# Altium schematic sheet Relay.SchDoc
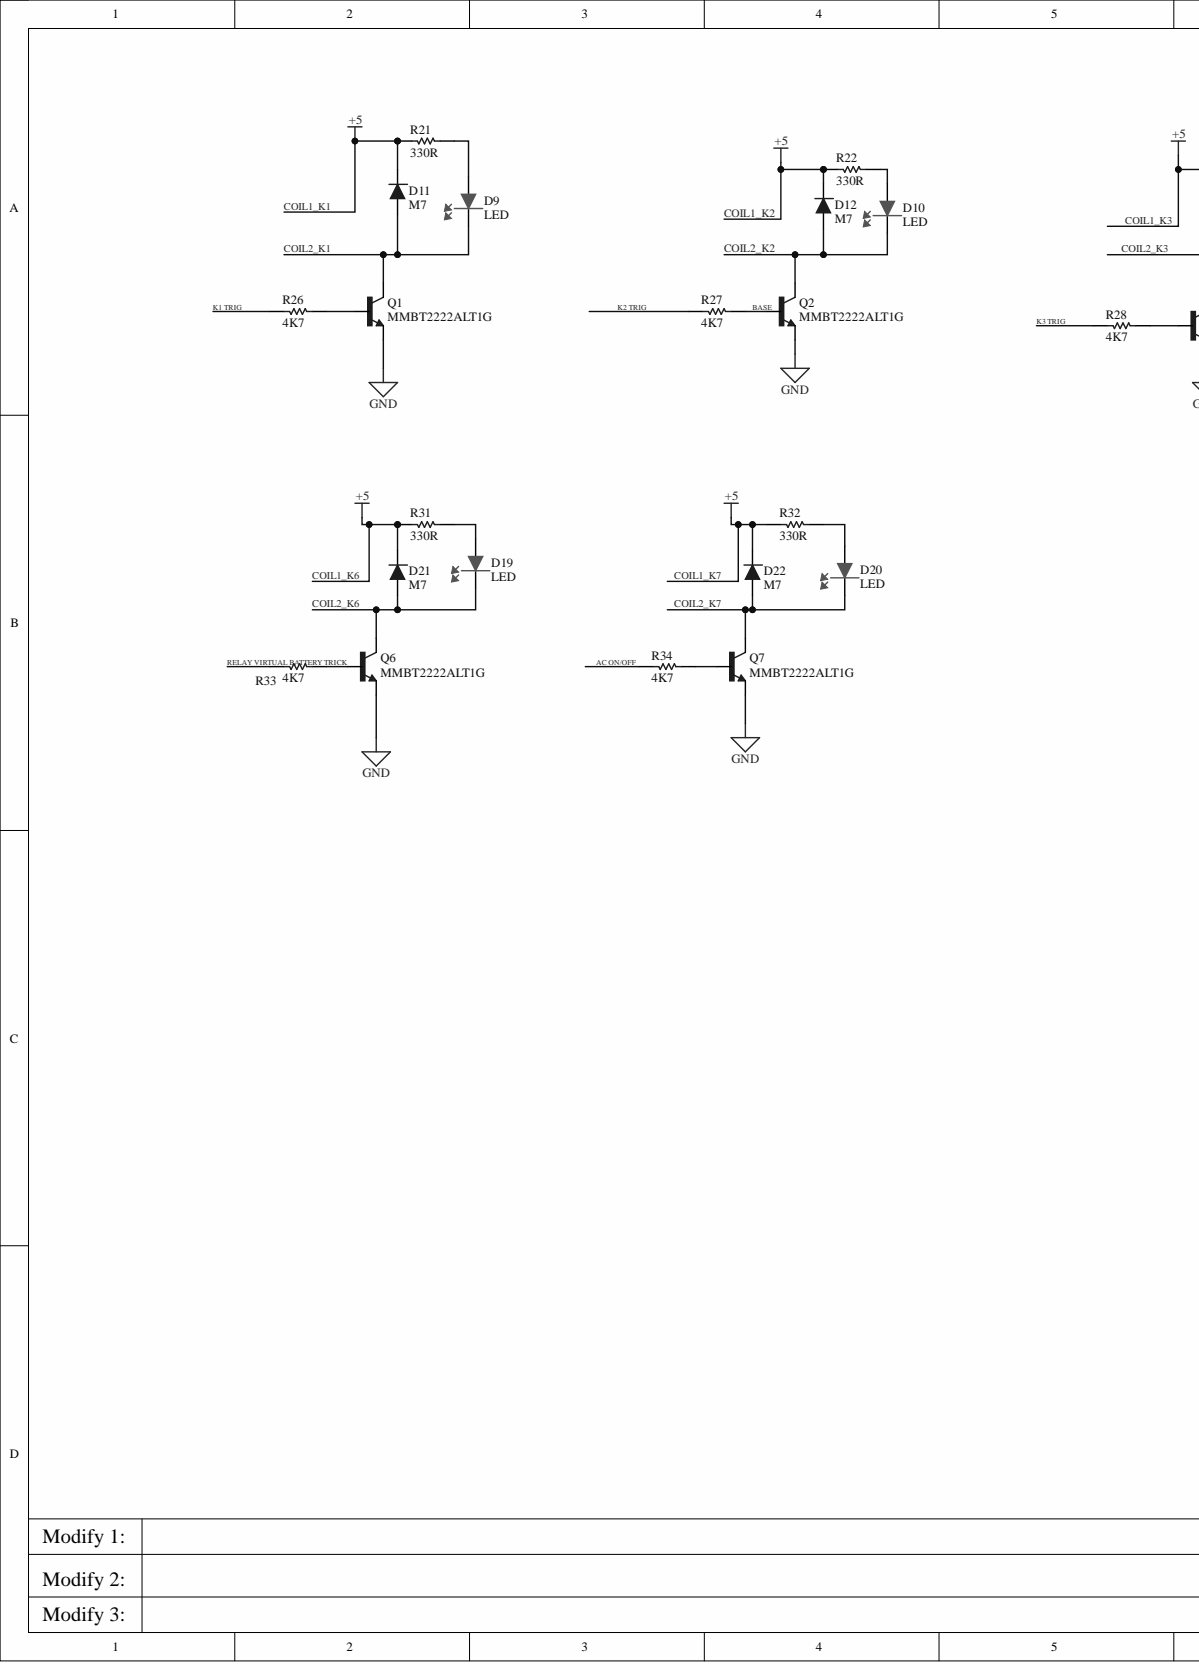
[diagram p0: Relay.SchDoc, left]
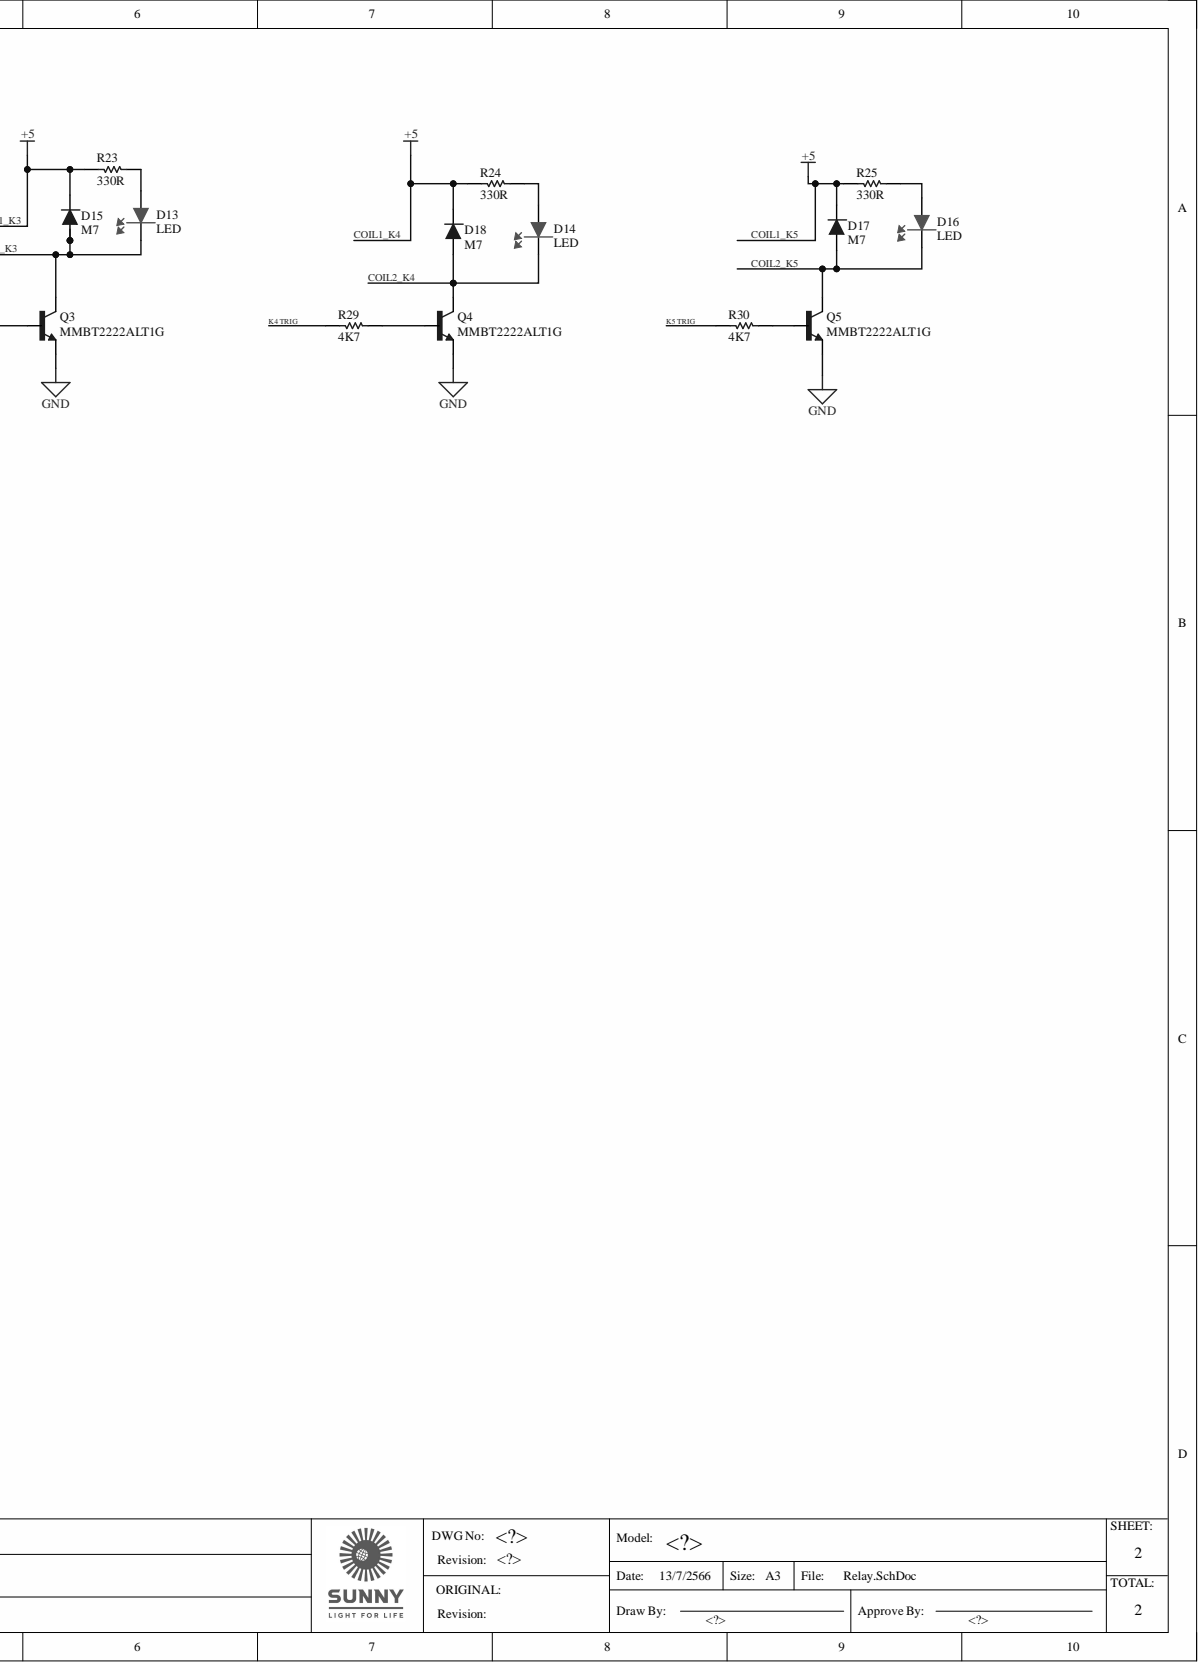
[diagram p1: Relay.SchDoc, right]

## Parts
D9  LED  [0805 (1205) LED]
D10  LED  [0805 (1205) LED]
D11  M7  [DO-214AC]
D12  M7  [DO-214AC]
D13  LED  [0805 (1205) LED]
D14  LED  [0805 (1205) LED]
D15  M7  [DO-214AC]
D16  LED  [0805 (1205) LED]
D17  M7  [DO-214AC]
D18  M7  [DO-214AC]
D19  LED  [0805 (1205) LED]
D20  LED  [0805 (1205) LED]
D21  M7  [DO-214AC]
D22  M7  [DO-214AC]
Q1  MMBT2222ALT1G  [SOT95P237X111-3N]
Q2  MMBT2222ALT1G  [SOT95P237X111-3N]
Q3  MMBT2222ALT1G  [SOT95P237X111-3N]
Q4  MMBT2222ALT1G  [SOT95P237X111-3N]
Q5  MMBT2222ALT1G  [SOT95P237X111-3N]
Q6  MMBT2222ALT1G  [SOT95P237X111-3N]
Q7  MMBT2222ALT1G  [SOT95P237X111-3N]
R21  330R  [0805 Modificado]
R22  330R  [0805 Modificado]
R23  330R  [0805 Modificado]
R24  330R  [0805 Modificado]
R25  330R  [0805 Modificado]
R26  4K7  [0805 Modificado]
R27  4K7  [0805 Modificado]
R28  4K7  [0805 Modificado]
R29  4K7  [0805 Modificado]
R30  4K7  [0805 Modificado]
R31  330R  [0805 Modificado]
R32  330R  [0805 Modificado]
R33  4K7  [0805 Modificado]
R34  4K7  [0805 Modificado]

## Nets
GND: Q1.2 Q2.2 Q3.2 Q4.2 Q5.2 Q6.2 Q7.2
AC ON/OFF: R34.2
BASE: Q2.1 R27.1
COIL1_K1: D11.K D12.K D15.K D17.K D18.K D21.K D22.K R21.2 R22.2 R23.2 R24.2 R25.2 R31.2 R32.2
COIL2_K1: D9.1 D11.A Q1.3
COIL2_K2: D10.1 D12.A Q2.3
COIL2_K3: D13.1 D15.A Q3.3
COIL2_K4: D14.1 D18.A Q4.3
COIL2_K5: D16.1 D17.A Q5.3
COIL2_K6: D19.1 D21.A Q6.3
COIL2_K7: D20.1 D22.A Q7.3
K1 TRIG: R26.2
K2 TRIG: R27.2
K3 TRIG: R28.2
K4 TRIG: R29.2
K5 TRIG: R30.2
RELAY VIRTUAL BATTERY TRICK: R33.2
NetD9_2: D9.2 R21.1
NetD10_2: D10.2 R22.1
NetD13_2: D13.2 R23.1
NetD14_2: D14.2 R24.1
NetD16_2: D16.2 R25.1
NetD19_2: D19.2 R31.1
NetD20_2: D20.2 R32.1
NetQ1_1: Q1.1 R26.1
NetQ3_1: Q3.1 R28.1
NetQ4_1: Q4.1 R29.1
NetQ5_1: Q5.1 R30.1
NetQ6_1: Q6.1 R33.1
NetQ7_1: Q7.1 R34.1

## Library data of the parts
D9 D10 D13 D14 D16 D19 D20 = LED RED (SMD): LED SMD  mcd,Red Color Red Diffused Lens; Component Kind: Standard; Library Name: PCB_Project_1.SCHLIB
D11 D12 D15 D17 D18 D21 D22 = Diode M7: M7 Rectifier Diode 1000V/1A . Package  DO-214AC (SMA); Code_JEDEC: DO-204-AL; Component Kind: Standard; ComponentLink1Description: Manufacturer Link; ComponentLink1URL: http://www.fairchildsemi.com/; ComponentLink2Description: Datasheet; ComponentLink2URL: http://www.fairchildsemi.com/ds/1N%2F1N4001.pdf; DatasheetVersion: 1998; LatestRevisionDate: 17-Jul-2002; LatestRevisionNote: Re-released for DXP Platform.; Library Name: PCB_Project_1.SCHLIB; PackageDescription: Diode, Axial; Body 5.2 x 2.7 mm (LxDia. max), Lead Dia. 0.86 mm (max); PackageReference: DO-41; PackageVersion: Aug-1999; Published: 8-Jun-2000; Publisher: Altium Limited
Q1-Q7 = MMBT2222ALT1G: Bipolar (BJT) Transistor NPN 40 V 600 mA 300MHz 225 mW Surface Mount SOT-23-3 (TO-236); MF: ON Semiconductor; Availability: In Stock; Check_prices: https://www.snapeda.com/parts/MMBT2222ALT1G/Onsemi/view-part/?ref=eda; Component Kind: Standard; Library Name: PCB_Project_1.SCHLIB; MP: MMBT2222ALT1G; Package: SOT-23-3 ON Semiconductor; Price: None; Purchase-URL: https://pricing.snapeda.com/search/part/MMBT2222ALT1G/?ref=eda; SnapEDA_Link: https://www.snapeda.com/parts/MMBT2222ALT1G/Onsemi/view-part/?ref=snap
R21-R32 = Resistor SMD 330R +/- 1% (CHIP): 'RES SMD 330 OHM 5% 1/8W 0805/0805W8F3300T5E; RMPN: RMELCRSTS010; Component Kind: Standard; Library Name: MCU POWER BOARD.SchLib
R33 = Resistor SMD 330R +/- 1% (CHIP): 'RES SMD 4700 OHM 5% 1/8W 0805/0805W8F3300T5E; RMPN: RMELCRSTS010; Component Kind: Standard; Library Name: MCU POWER BOARD.SchLib
R34 = Resistor SMD 330R +/- 1% (CHIP): 'RES SMD 4K7 5% 1/8W 0805/0805W8F3300T5E; RMPN: RMELCRSTS010; Component Kind: Standard; Library Name: MCU POWER BOARD.SchLib
